# Altium schematic sheet MotherBoard_PowerSupply.SchDoc
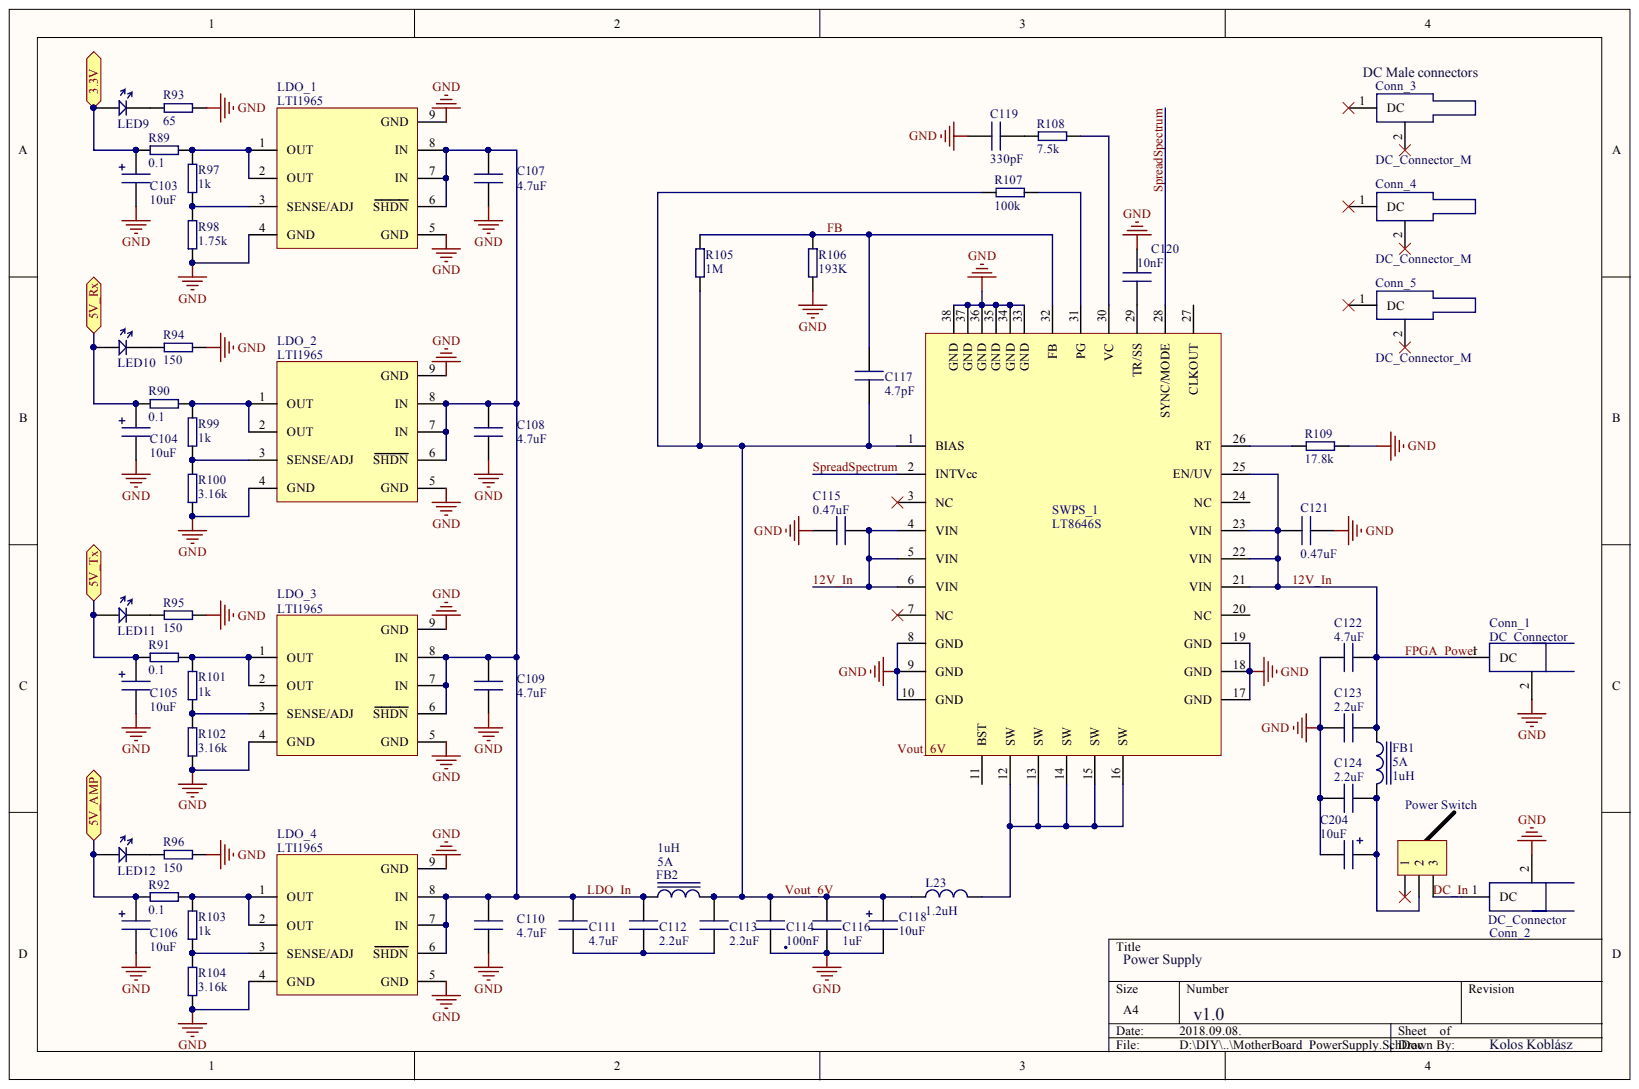
[diagram p0: MotherBoard_PowerSupply.SchDoc]

## Parts
C103  value 10uF  (hidden comment: Cap2)
C104  value 10uF  (hidden comment: Cap2)
C105  value 10uF  (hidden comment: Cap2)
C106  value 10uF  (hidden comment: Cap2)
C107  value 4.7uF  (hidden comment: Cap1)
C108  value 4.7uF  (hidden comment: Cap1)
C109  value 4.7uF  (hidden comment: Cap1)
C110  value 4.7uF  (hidden comment: Cap1)
C111  value 4.7uF  (hidden comment: Cap1)
C112  value 2.2uF  (hidden comment: Cap1)
C113  value 2.2uF  (hidden comment: Cap1)
C114  value 100nF  (hidden comment: Cap1)
C115  value 0.47uF  (hidden comment: Cap1)
C116  value 1uF  (hidden comment: Cap1)
C117  value 4.7pF  (hidden comment: Cap1)
C118  value 10uF  (hidden comment: Cap2)
C119  value 330pF  (hidden comment: Cap1)
C120  value 10nF  (hidden comment: Cap1)
C121  value 0.47uF  (hidden comment: Cap1)
C122  value 4.7uF  (hidden comment: Cap1)
C123  value 2.2uF  (hidden comment: Cap1)
C124  value 2.2uF  (hidden comment: Cap1)
C204  value 10uF  (hidden comment: Cap2)
Conn_1  DC_Connector
Conn_2  DC_Connector
Conn_3  DC_Connector_M
Conn_4  DC_Connector_M
Conn_5  DC_Connector_M
FB1  value 1uH  MaxCurent: 5A  [THD]  (hidden comment: Ferrit Bead)
FB2  value 1uH  MaxCurent: 5A  [THD]  (hidden comment: Ferrit Bead)
L23  value 1.2uH  [0805]  (hidden comment: Inductor)
LDO_1  LTI1965  [LT1965]
LDO_2  LTI1965  [LT1965]
LDO_3  LTI1965  [LT1965]
LDO_4  LTI1965  [LT1965]
LED9  LED_Green  [0805]  (value not drawn)
LED10  LED_Green  [0805]  (value not drawn)
LED11  LED_Green  [0805]  (value not drawn)
LED12  LED_Green  [0805]  (value not drawn)
Power_Switch  Switch  [Switch]
R89  value 0.1  [SMD]  (hidden comment: Resistor)
R90  value 0.1  [SMD]  (hidden comment: Resistor)
R91  value 0.1  [SMD]  (hidden comment: Resistor)
R92  value 0.1  [SMD]  (hidden comment: Resistor)
R93  value 65  [SMD]  (hidden comment: Resistor)
R94  value 150  [SMD]  (hidden comment: Resistor)
R95  value 150  [SMD]  (hidden comment: Resistor)
R96  value 150  [SMD]  (hidden comment: Resistor)
R97  value 1k  [SMD]  (hidden comment: Resistor)
R98  value 1.75k  [SMD]  (hidden comment: Resistor)
R99  value 1k  [SMD]  (hidden comment: Resistor)
R100  value 3.16k  [SMD]  (hidden comment: Resistor)
R101  value 1k  [SMD]  (hidden comment: Resistor)
R102  value 3.16k  [SMD]  (hidden comment: Resistor)
R103  value 1k  [SMD]  (hidden comment: Resistor)
R104  value 3.16k  [SMD]  (hidden comment: Resistor)
R105  value 1M  [SMD]  (hidden comment: Resistor)
R106  value 193K  [SMD]  (hidden comment: Resistor)
R107  value 100k  [SMD]  (hidden comment: Resistor)
R108  value 7.5k  [SMD]  (hidden comment: Resistor)
R109  value 17.8k  [SMD]  (hidden comment: Resistor)
SWPS_1  LT8646S

## Ports
3.3V, 5V_AMP, 5V_RX, 5V_TX

## Nets
GND: C103.2 C104.2 C105.2 C106.2 C107.1 C108.1 C109.1 C110.1 C114.2 C115.2 C116.2 C118.2 C119.1 C120.1 C121.2 C122.1 C123.1 C124.1 C204.2 LDO_1.4(GND) LDO_1.5(GND) LDO_1.9(GND) LDO_2.4(GND) LDO_2.5(GND) LDO_2.9(GND) LDO_3.4(GND) LDO_3.5(GND) LDO_3.9(GND) LDO_4.4(GND) LDO_4.5(GND) LDO_4.9(GND) R93.2 R94.2 R95.2 R96.2 R98.1 R100.1 R102.1 R104.1 R106.2 R109.2 SWPS_1.8(GND) SWPS_1.9(GND) SWPS_1.10(GND) SWPS_1.17(GND) SWPS_1.18(GND) SWPS_1.19(GND) SWPS_1.33(GND) SWPS_1.34(GND) SWPS_1.35(GND) SWPS_1.36(GND) SWPS_1.37(GND) SWPS_1.38(GND)
3.3V: C103.1 LED9.2 R89.1 ; port 3.3V
5V_AMP: C106.1 LED12.2 R92.1 ; port 5V_AMP
12V_IN: C115.1 C121.1 C122.2 C123.2 Conn_1.1 FB1.1 SWPS_1.4(VIN) SWPS_1.5(VIN) SWPS_1.6(VIN) SWPS_1.21(VIN) SWPS_1.22(VIN) SWPS_1.23(VIN) SWPS_1.25(EN/UV)
DC_IN: Conn_2.1 Power_Switch.3
FB: C117.1 R105.1 R106.1 SWPS_1.32(FB)
LDO_IN: C107.2 C108.2 C109.2 C110.2 C111.2 C112.2 FB2.1 LDO_1.6(SHDN) LDO_1.7(IN) LDO_1.8(IN) LDO_2.6(SHDN) LDO_2.7(IN) LDO_2.8(IN) LDO_3.6(SHDN) LDO_3.7(IN) LDO_3.8(IN) LDO_4.6(SHDN) LDO_4.7(IN) LDO_4.8(IN)
SPREADSPECTRUM: SWPS_1.2(INTVcc) SWPS_1.28(SYNC/MODE)
VOUT_6V: C113.2 C114.1 C116.1 C117.2 C118.1 FB2.2 L23.1 R105.2 R107.2 SWPS_1.1(BIAS)
NetC104_1: C104.1 LED10.2 R90.1 ; port 5V_RX
NetC105_1: C105.1 LED11.2 R91.1 ; port 5V_TX
NetC111_1: C111.1 C112.1 C113.1
NetC119_2: C119.2 R108.1
NetC120_2: C120.2 SWPS_1.29(TR/SS)
NetC124_2: C124.2 C204.1 FB1.2 Power_Switch.2
NetL23_2: L23.2 SWPS_1.12(SW) SWPS_1.13(SW) SWPS_1.14(SW) SWPS_1.15(SW) SWPS_1.16(SW)
NetLDO_1_1: LDO_1.1(OUT) LDO_1.2(OUT) R89.2 R97.2
NetLDO_1_3: LDO_1.3(SENSE/ADJ) R97.1 R98.2
NetLDO_2_1: LDO_2.1(OUT) LDO_2.2(OUT) R90.2 R99.2
NetLDO_2_3: LDO_2.3(SENSE/ADJ) R99.1 R100.2
NetLDO_3_1: LDO_3.1(OUT) LDO_3.2(OUT) R91.2 R101.2
NetLDO_3_3: LDO_3.3(SENSE/ADJ) R101.1 R102.2
NetLDO_4_1: LDO_4.1(OUT) LDO_4.2(OUT) R92.2 R103.2
NetLDO_4_3: LDO_4.3(SENSE/ADJ) R103.1 R104.2
NetLED9_1: LED9.1 R93.1
NetLED10_1: LED10.1 R94.1
NetLED11_1: LED11.1 R95.1
NetLED12_1: LED12.1 R96.1
NetR107_1: R107.1 SWPS_1.31(PG)
NetR108_2: R108.2 SWPS_1.30(VC)
NetR109_1: R109.1 SWPS_1.26(RT)

Not connected: Conn_1 2; Conn_2 2; Conn_3 1 2; Conn_4 1 2; Conn_5 1 2; Power_Switch 1; SWPS_1 3(NC) 7(NC) 11(BST) 20(NC) 24(NC) 27(CLKOUT)

## Library data of the parts
C103-C106 C118 C204 = Cap2: SMD Polarized Capacitor; MaxVolt: 16V; Precision: 1%; Component Kind: Standard; Library Name: RadioProjectSchLib.SchLib
C107-C117 C119-C124 = Cap1: SMD Capacitor; MaxVolt: 16V; Precision: 1%; Component Kind: Standard; Library Name: RadioProjectSchLib.SchLib
Conn_1 Conn_2 = DC_Connector: Coaxial DC connector - female; Component Kind: Standard; Library Name: RadioProjectSchLib.SchLib
Conn_3-Conn_5 = DC_Connector_M: Coaxial DC connector - male; Mateing Part: CP-037A-ND; Imax: 5A; Vmax: 30V; Component Kind: Standard; Digi Key Order Code: EP501A-ND; Library Name: RadioProjectSchLib.SchLib; Size: 2.1mm - 5.5mm
FB1 FB2 = FerritBead: PartNumber: FB20022-4B-RC; Precision: 10%; Component Kind: Standard; Description: Ferrit Bead; Digi Key Order Code: FB20022-4B-RC-ND; Library Name: RadioProjectSchLib.SchLib
L23 = Ind1: SMD Inductor; MaxCurent: 4.25A; Precision: 10%; Component Kind: Standard; Digi Key Order Code: 495-6782-1-ND; Library Name: RadioProjectSchLib.SchLib
LDO_1-LDO_4 = LTI1965: Low Dropout Linear Regulator; Manufacturer: Analog Devices; Order Code: LT1965EDD#PBF; Component Kind: Standard; Library Name: RadioProjectSchLib.SchLib; Package Description: 8-Lead Plastic DFN; PartNumber: LTI1965
LED9-LED12 = LED_Green: Diode; Manufacturer: LITEON; Partnumber: LTST-C171KGKT; ForwardCurrent: 20mA; ForwardVoltage: 2V; MaxForwardCurrent: 30mA; MaxRevVoltage: 5V; OrderCode: 160-1426-1-ND; Type: Green; Component Kind: Standard; Library Name: RadioProjectSchLib.SchLib
Power_Switch = Switch: 3 state switch; PinNumber: 3; Pitch: 2.54mm; Component Kind: Standard; Digi Key Order Code: EG2355-ND; Library Name: RadioProjectSchLib.SchLib; Type: THD 3 state
R89-R109 = Res1: SMD Resistor; MaxPower: 0.1W; Precision: 1%; Component Kind: Standard; Library Name: RadioProjectSchLib.SchLib
SWPS_1 = LT8646S: Step-Down Silent Switcher Power Supply; Manufacturer: Analog Devices; Order Code: LT8646SEV#PBF; Component Kind: Standard; Library Name: RadioProjectSchLib.SchLib; Package Description: LQFN; PartNumber: LT8646S
